# Altium schematic sheet Sheet5.SchDoc
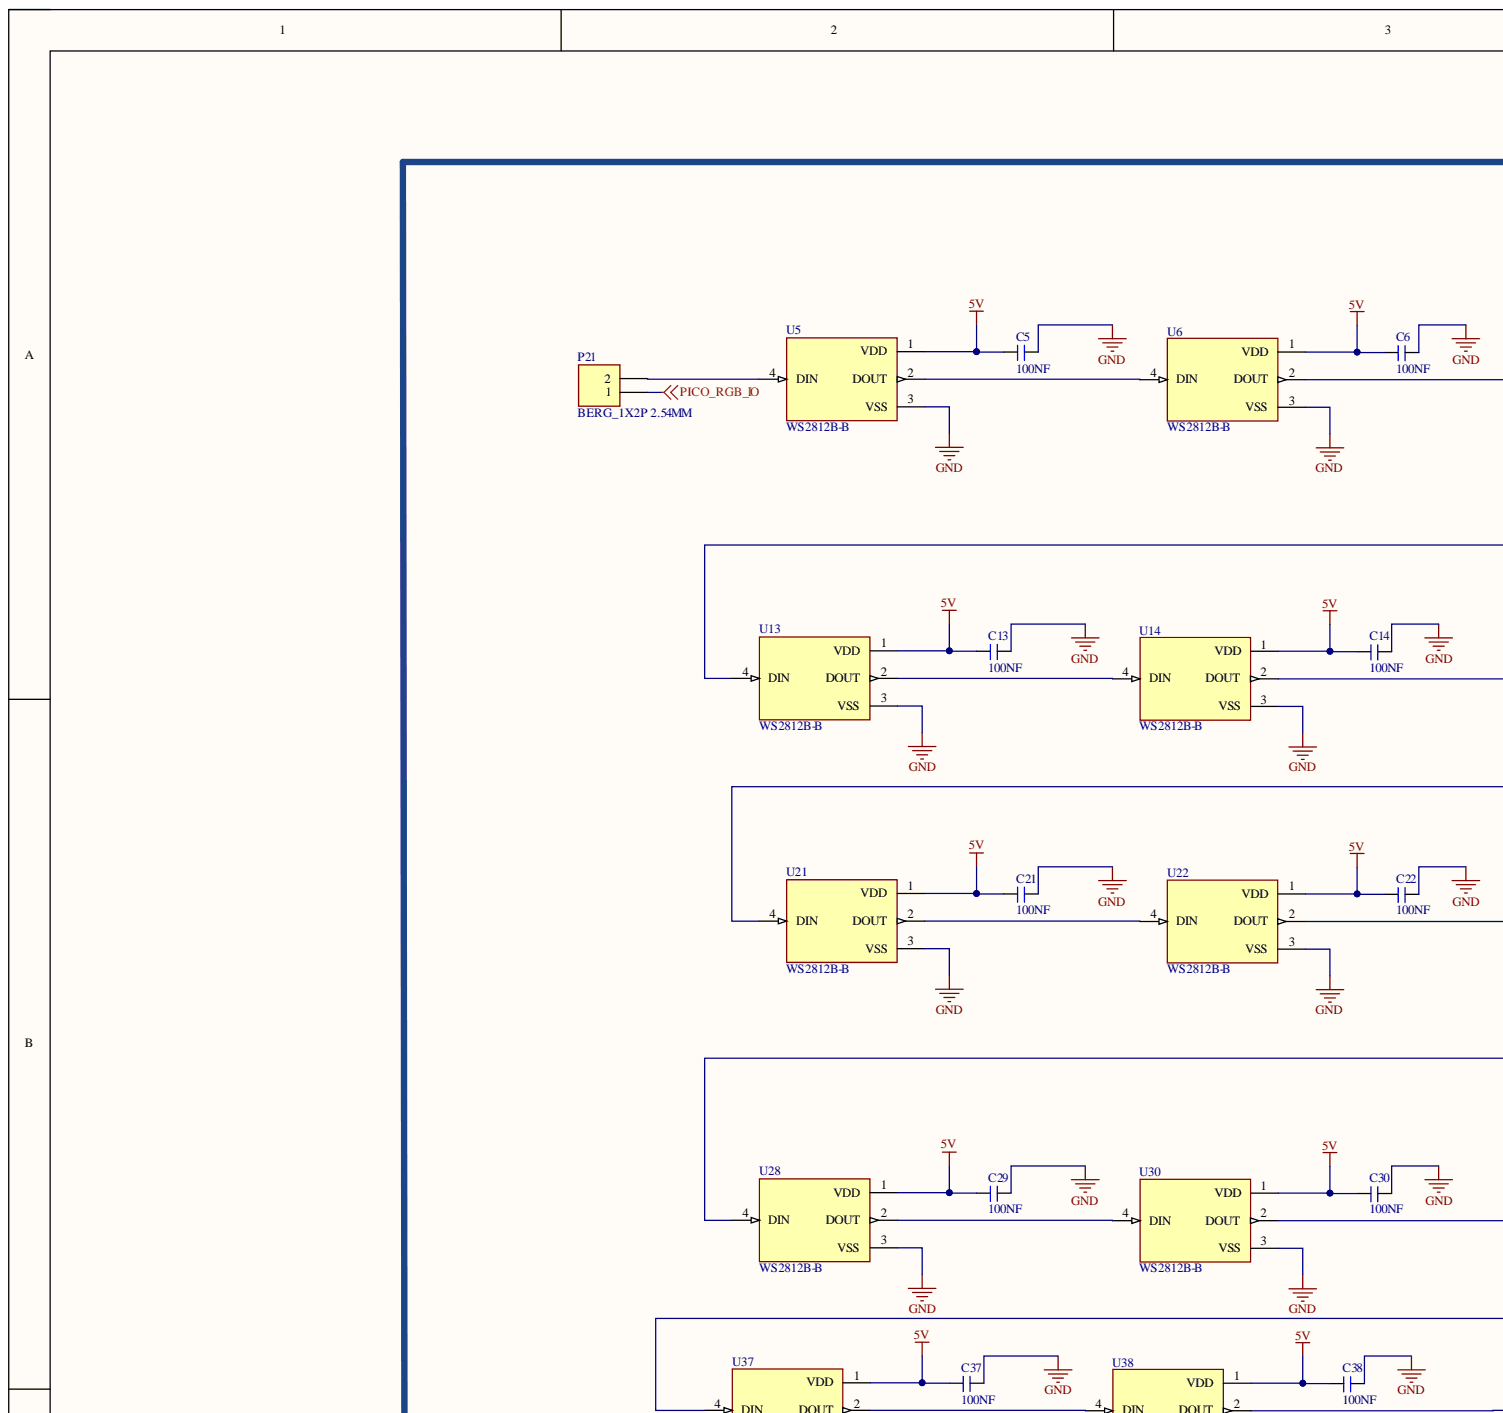
[diagram p0: Sheet5.SchDoc, top left]
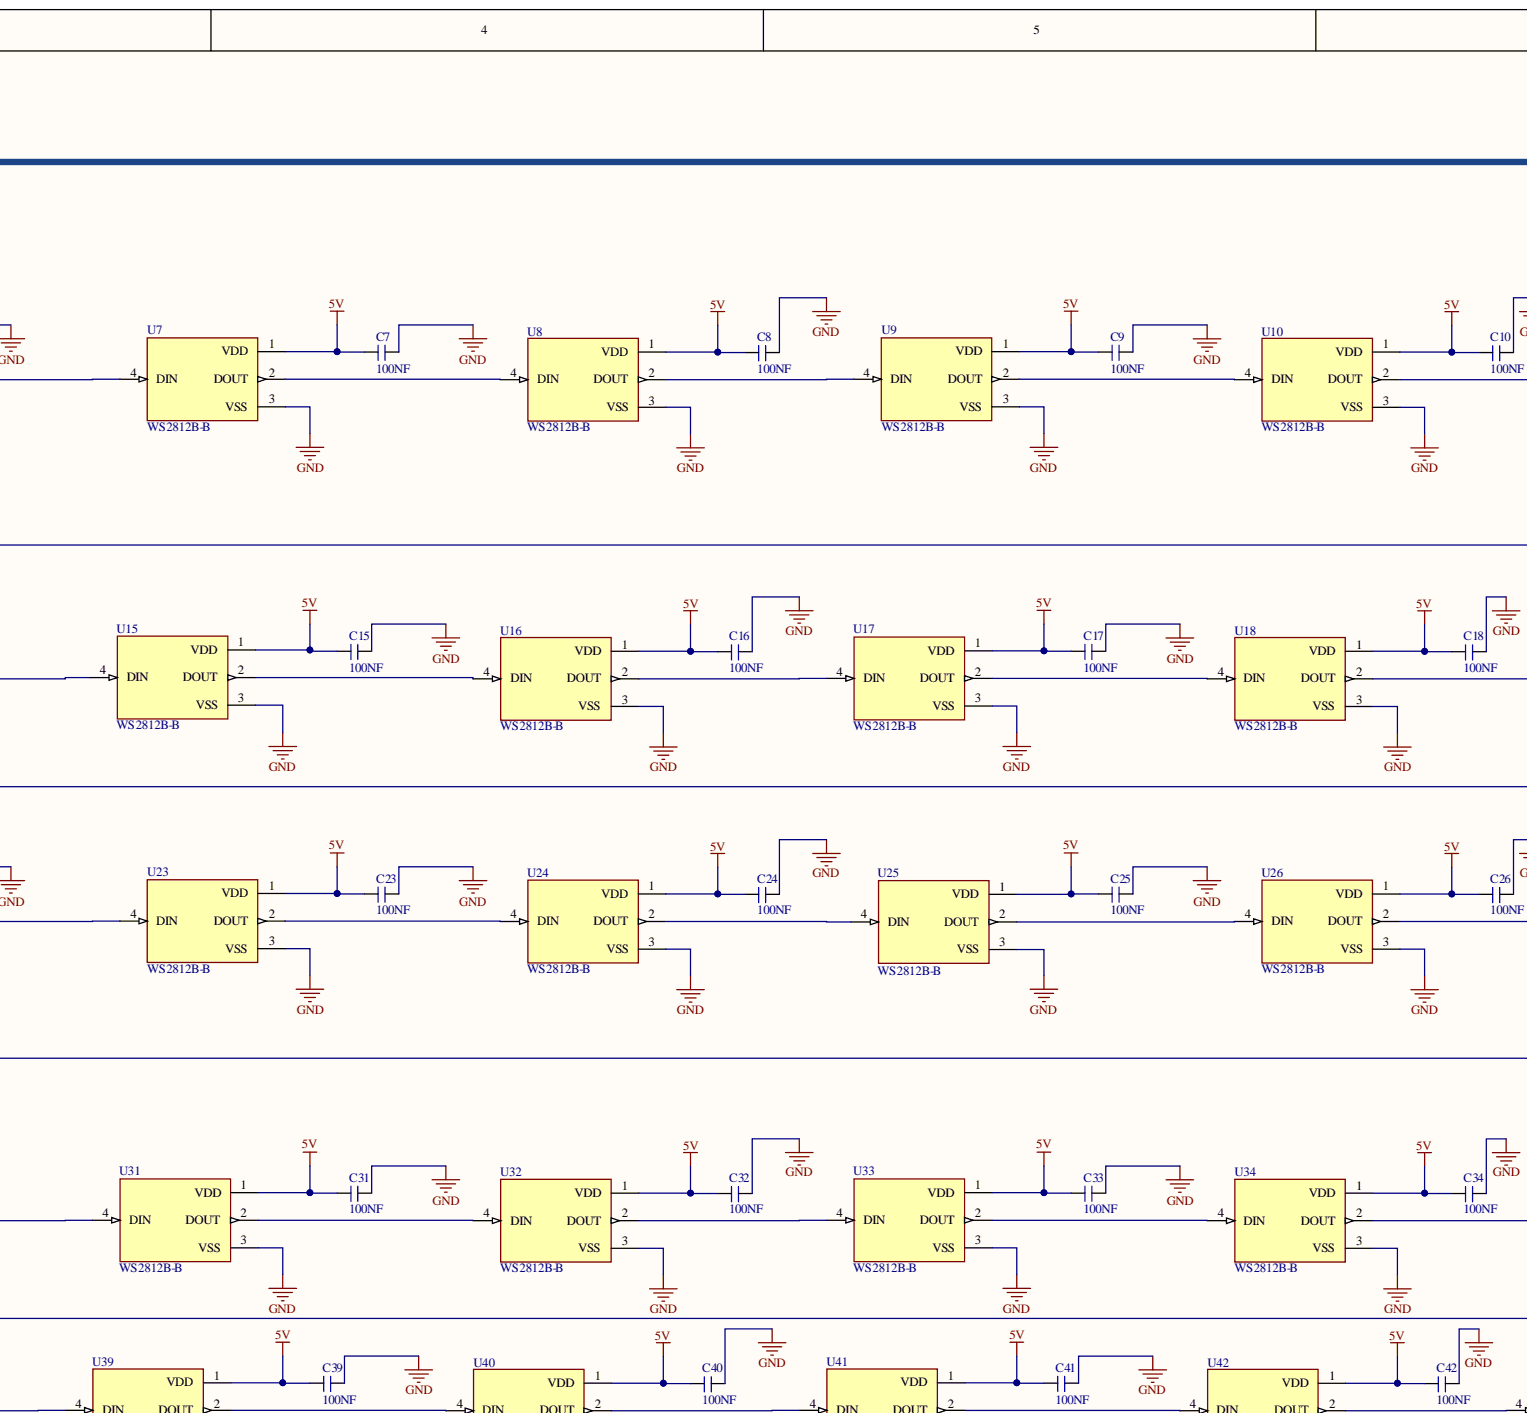
[diagram p1: Sheet5.SchDoc, top centre]
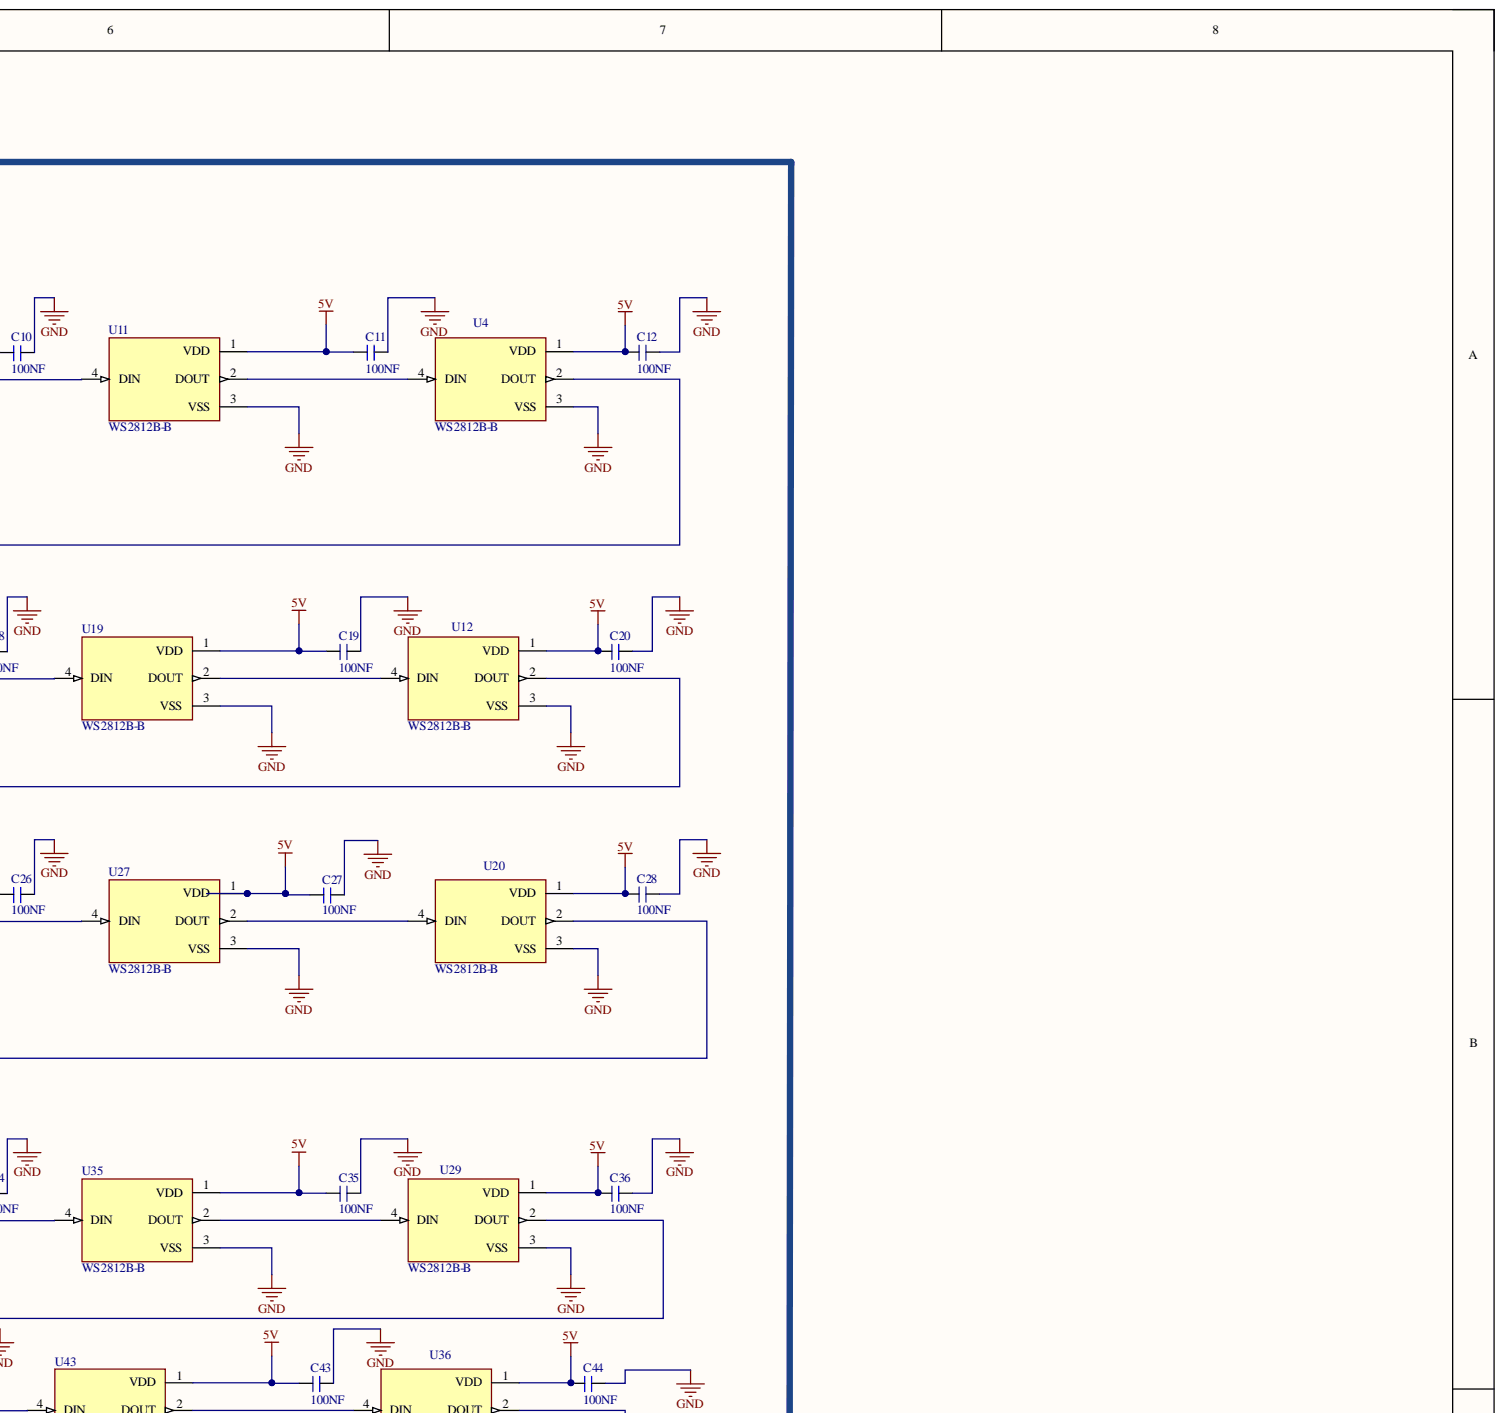
[diagram p2: Sheet5.SchDoc, top right]
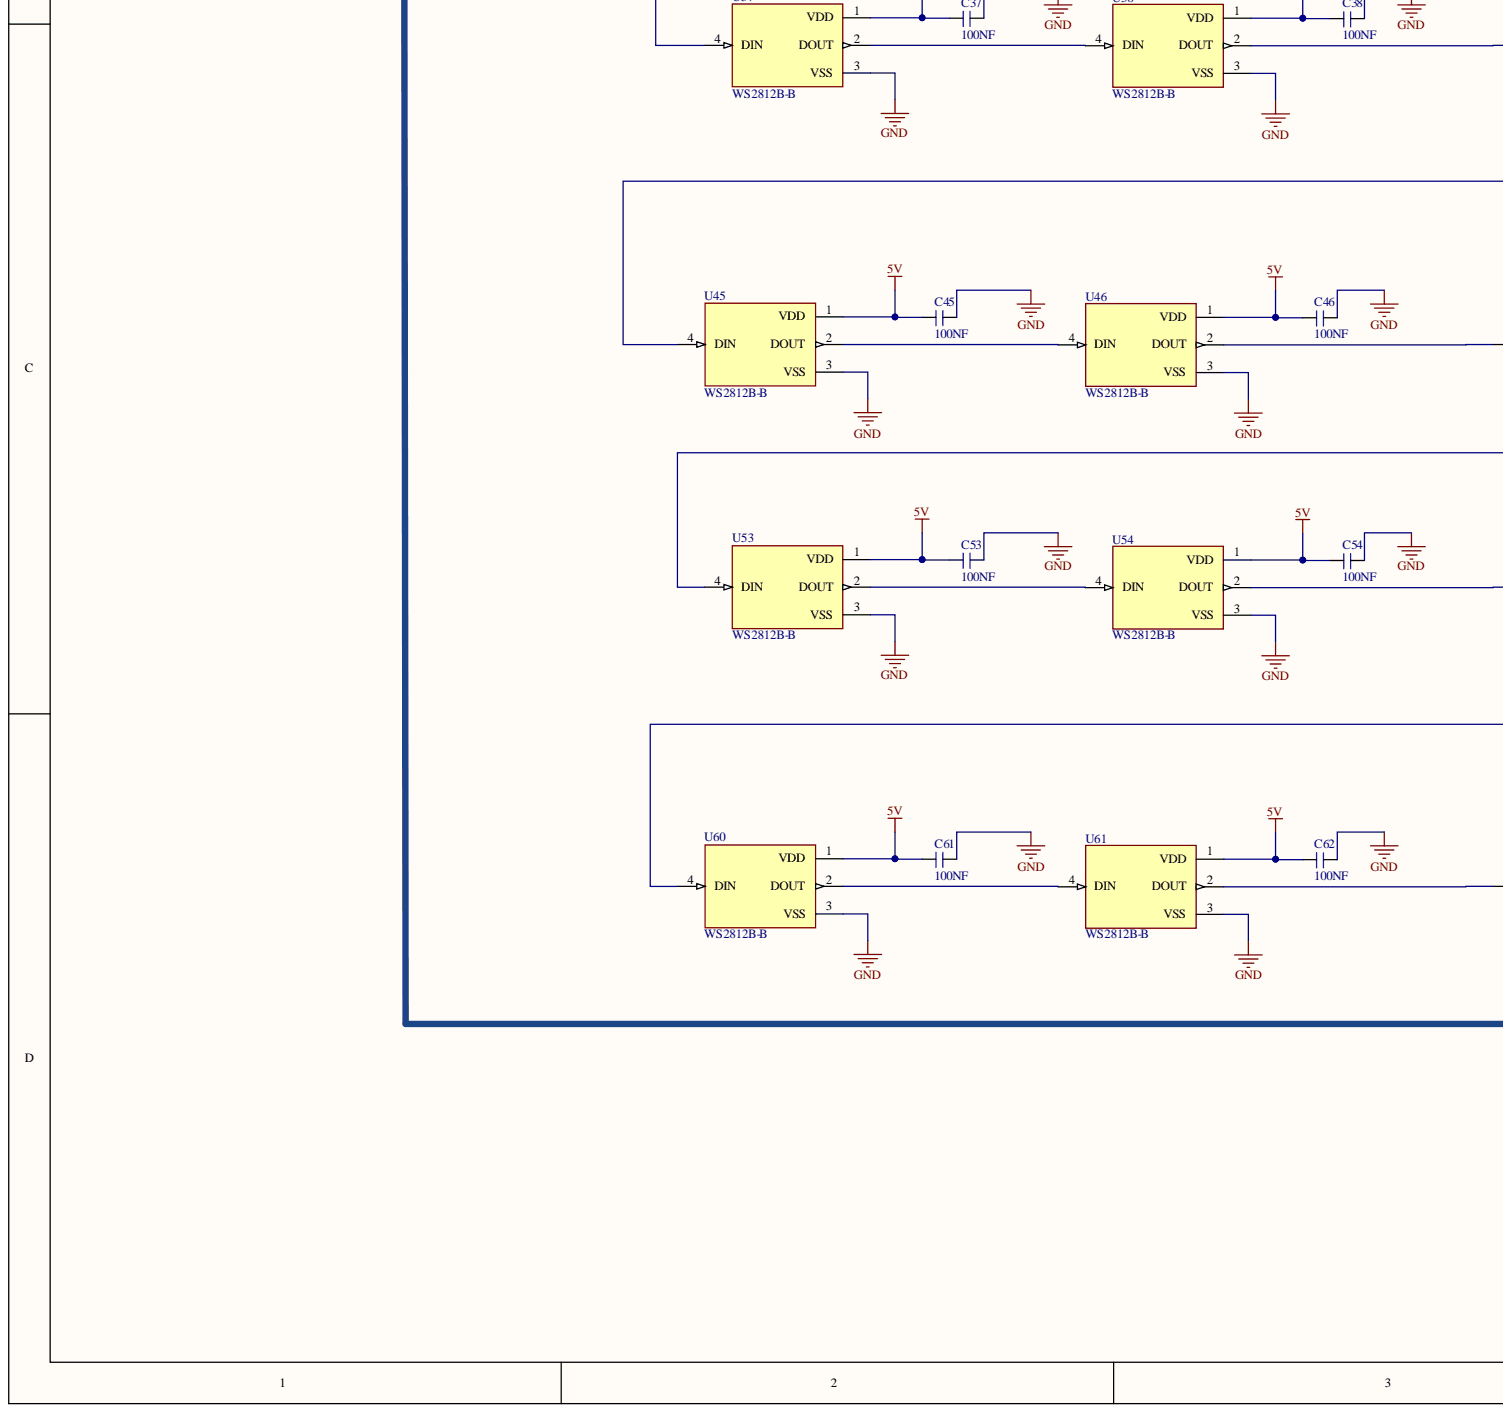
[diagram p3: Sheet5.SchDoc, bottom left]
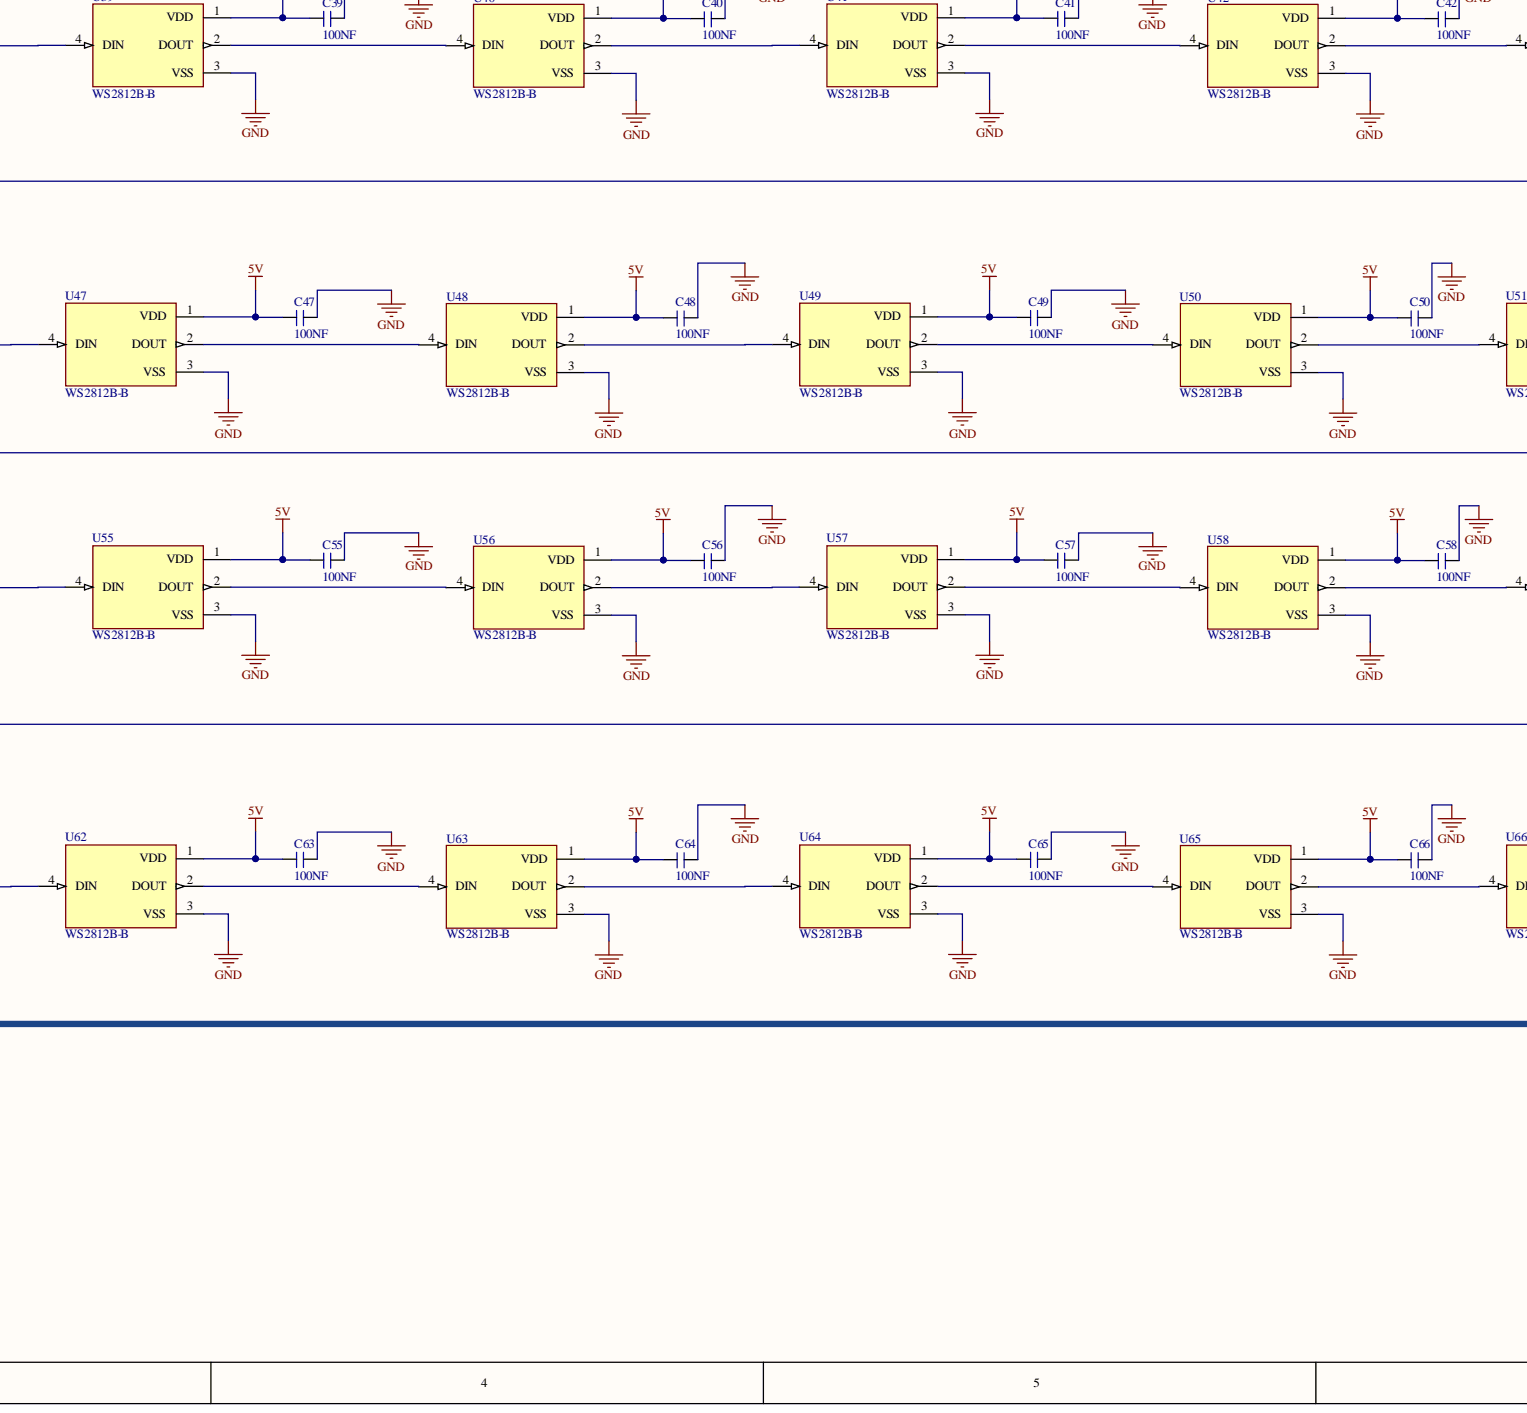
[diagram p4: Sheet5.SchDoc, bottom centre]
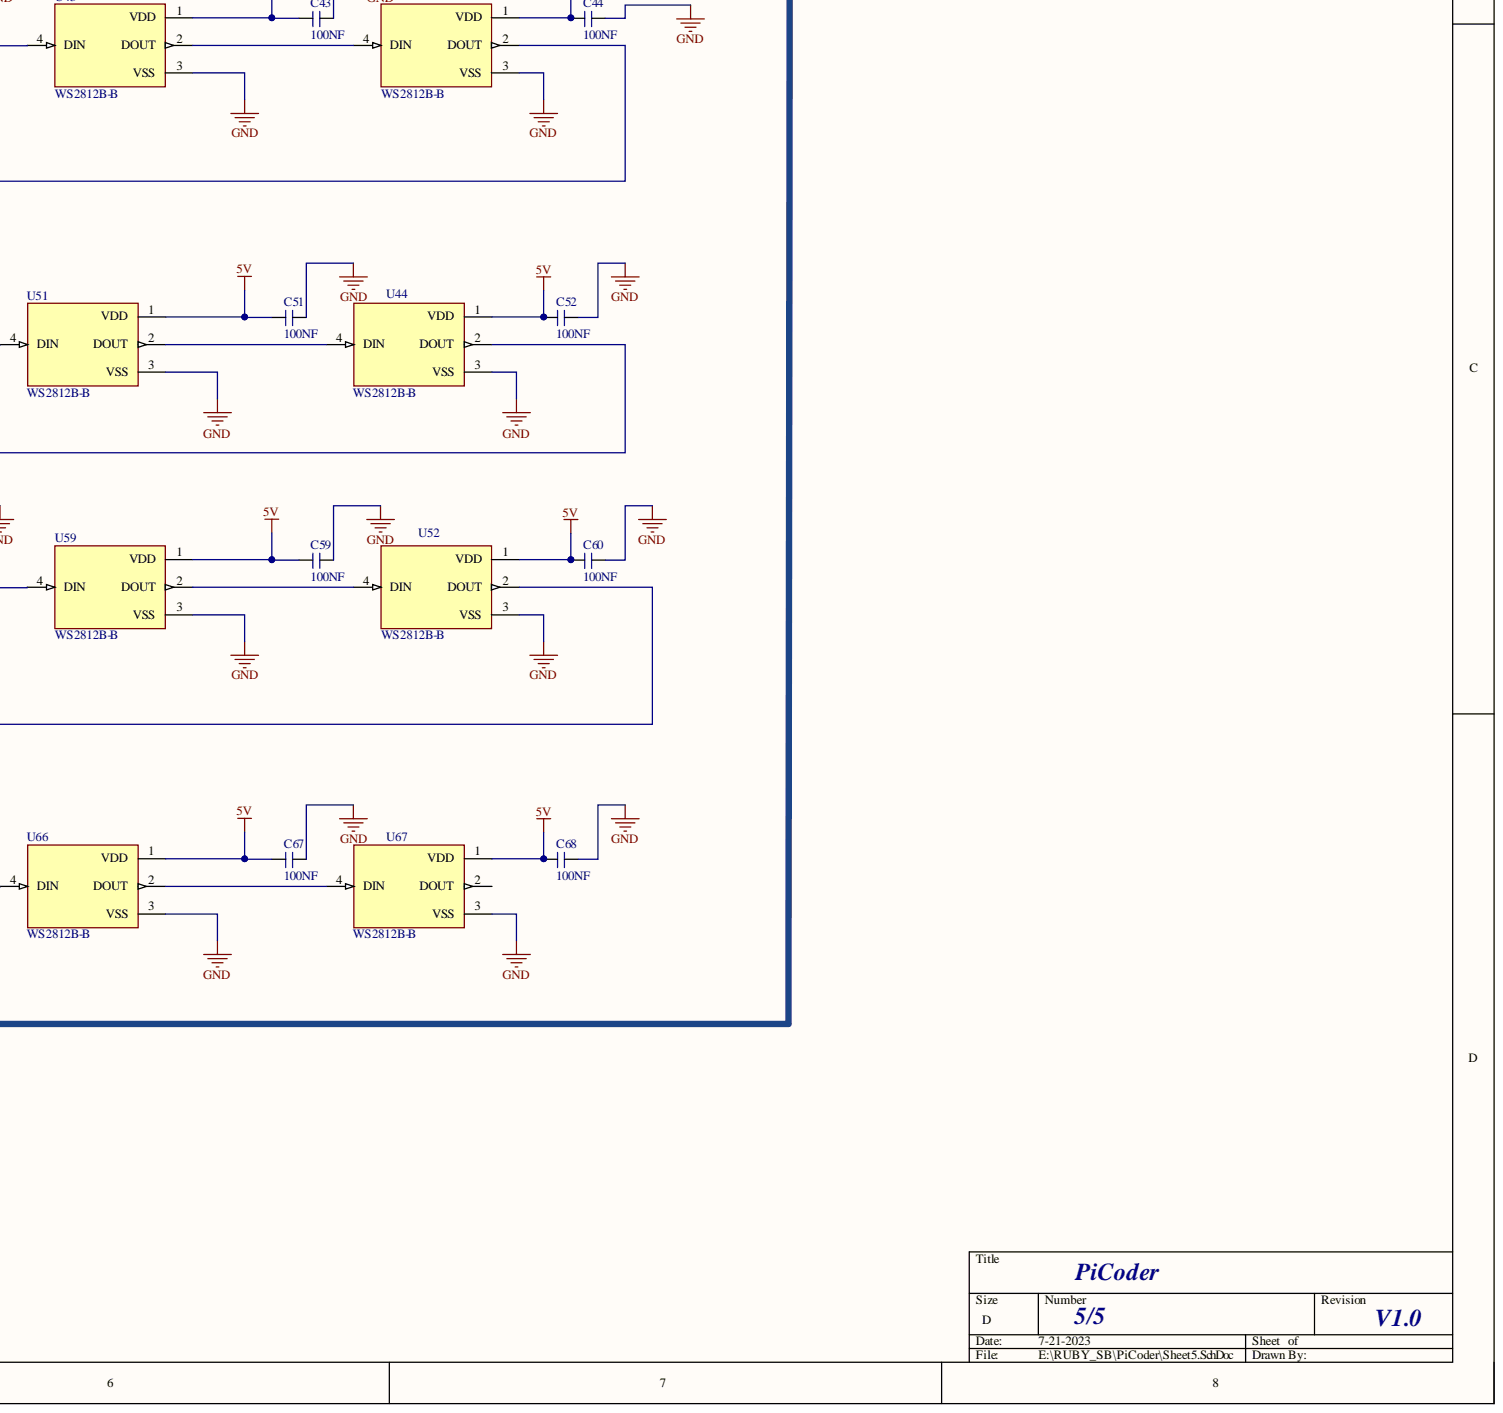
[diagram p5: Sheet5.SchDoc, bottom right]

## Parts
C5  100NF  [C_0603]
C6  100NF  [C_0603]
C7  100NF  [C_0603]
C8  100NF  [C_0603]
C9  100NF  [C_0603]
C10  100NF  [C_0603]
C11  100NF  [C_0603]
C12  100NF  [C_0603]
C13  100NF  [C_0603]
C14  100NF  [C_0603]
C15  100NF  [C_0603]
C16  100NF  [C_0603]
C17  100NF  [C_0603]
C18  100NF  [C_0603]
C19  100NF  [C_0603]
C20  100NF  [C_0603]
C21  100NF  [C_0603]
C22  100NF  [C_0603]
C23  100NF  [C_0603]
C24  100NF  [C_0603]
C25  100NF  [C_0603]
C26  100NF  [C_0603]
C27  100NF  [C_0603]
C28  100NF  [C_0603]
C29  100NF  [C_0603]
C30  100NF  [C_0603]
C31  100NF  [C_0603]
C32  100NF  [C_0603]
C33  100NF  [C_0603]
C34  100NF  [C_0603]
C35  100NF  [C_0603]
C36  100NF  [C_0603]
C37  100NF  [C_0603]
C38  100NF  [C_0603]
C39  100NF  [C_0603]
C40  100NF  [C_0603]
C41  100NF  [C_0603]
C42  100NF  [C_0603]
C43  100NF  [C_0603]
C44  100NF  [C_0603]
C45  100NF  [C_0603]
C46  100NF  [C_0603]
C47  100NF  [C_0603]
C48  100NF  [C_0603]
C49  100NF  [C_0603]
C50  100NF  [C_0603]
C51  100NF  [C_0603]
C52  100NF  [C_0603]
C53  100NF  [C_0603]
C54  100NF  [C_0603]
C55  100NF  [C_0603]
C56  100NF  [C_0603]
C57  100NF  [C_0603]
C58  100NF  [C_0603]
C59  100NF  [C_0603]
C60  100NF  [C_0603]
C61  100NF  [C_0603]
C62  100NF  [C_0603]
C63  100NF  [C_0603]
C64  100NF  [C_0603]
C65  100NF  [C_0603]
C66  100NF  [C_0603]
C67  100NF  [C_0603]
C68  100NF  [C_0603]
P21  BERG_1X2P 2.54MM  [2P_2.54MM]
U4  WS2812B-B  [WS2812B_RGB]
U5  WS2812B-B  [WS2812B_RGB]
U6  WS2812B-B  [WS2812B_RGB]
U7  WS2812B-B  [WS2812B_RGB]
U8  WS2812B-B  [WS2812B_RGB]
U9  WS2812B-B  [WS2812B_RGB]
U10  WS2812B-B  [WS2812B_RGB]
U11  WS2812B-B  [WS2812B_RGB]
U12  WS2812B-B  [WS2812B_RGB]
U13  WS2812B-B  [WS2812B_RGB]
U14  WS2812B-B  [WS2812B_RGB]
U15  WS2812B-B  [WS2812B_RGB]
U16  WS2812B-B  [WS2812B_RGB]
U17  WS2812B-B  [WS2812B_RGB]
U18  WS2812B-B  [WS2812B_RGB]
U19  WS2812B-B  [WS2812B_RGB]
U20  WS2812B-B  [WS2812B_RGB]
U21  WS2812B-B  [WS2812B_RGB]
U22  WS2812B-B  [WS2812B_RGB]
U23  WS2812B-B  [WS2812B_RGB]
U24  WS2812B-B  [WS2812B_RGB]
U25  WS2812B-B  [WS2812B_RGB]
U26  WS2812B-B  [WS2812B_RGB]
U27  WS2812B-B  [WS2812B_RGB]
U28  WS2812B-B  [WS2812B_RGB]
U29  WS2812B-B  [WS2812B_RGB]
U30  WS2812B-B  [WS2812B_RGB]
U31  WS2812B-B  [WS2812B_RGB]
U32  WS2812B-B  [WS2812B_RGB]
U33  WS2812B-B  [WS2812B_RGB]
U34  WS2812B-B  [WS2812B_RGB]
U35  WS2812B-B  [WS2812B_RGB]
U36  WS2812B-B  [WS2812B_RGB]
U37  WS2812B-B  [WS2812B_RGB]
U38  WS2812B-B  [WS2812B_RGB]
U39  WS2812B-B  [WS2812B_RGB]
U40  WS2812B-B  [WS2812B_RGB]
U41  WS2812B-B  [WS2812B_RGB]
U42  WS2812B-B  [WS2812B_RGB]
U43  WS2812B-B  [WS2812B_RGB]
U44  WS2812B-B  [WS2812B_RGB]
U45  WS2812B-B  [WS2812B_RGB]
U46  WS2812B-B  [WS2812B_RGB]
U47  WS2812B-B  [WS2812B_RGB]
U48  WS2812B-B  [WS2812B_RGB]
U49  WS2812B-B  [WS2812B_RGB]
U50  WS2812B-B  [WS2812B_RGB]
U51  WS2812B-B  [WS2812B_RGB]
U52  WS2812B-B  [WS2812B_RGB]
U53  WS2812B-B  [WS2812B_RGB]
U54  WS2812B-B  [WS2812B_RGB]
U55  WS2812B-B  [WS2812B_RGB]
U56  WS2812B-B  [WS2812B_RGB]
U57  WS2812B-B  [WS2812B_RGB]
U58  WS2812B-B  [WS2812B_RGB]
U59  WS2812B-B  [WS2812B_RGB]
U60  WS2812B-B  [WS2812B_RGB]
U61  WS2812B-B  [WS2812B_RGB]
U62  WS2812B-B  [WS2812B_RGB]
U63  WS2812B-B  [WS2812B_RGB]
U64  WS2812B-B  [WS2812B_RGB]
U65  WS2812B-B  [WS2812B_RGB]
U66  WS2812B-B  [WS2812B_RGB]
U67  WS2812B-B  [WS2812B_RGB]

## Nets
5V: C5.1 C6.1 C7.1 C8.1 C9.1 C10.1 C11.1 C12.1 C13.1 C14.1 C15.1 C16.1 C17.1 C18.1 C19.1 C20.1 C21.1 C22.1 C23.1 C24.1 C25.1 C26.1 C27.1 C28.1 C29.1 C30.1 C31.1 C32.1 C33.1 C34.1 C35.1 C36.1 C37.1 C38.1 C39.1 C40.1 C41.1 C42.1 C43.1 C44.1 C45.1 C46.1 C47.1 C48.1 C49.1 C50.1 C51.1 C52.1 C53.1 C54.1 C55.1 C56.1 C57.1 C58.1 C59.1 C60.1 C61.1 C62.1 C63.1 C64.1 C65.1 C66.1 C67.1 C68.1 U4.1(VDD) U5.1(VDD) U6.1(VDD) U7.1(VDD) U8.1(VDD) U9.1(VDD) U10.1(VDD) U11.1(VDD) U12.1(VDD) U13.1(VDD) U14.1(VDD) U15.1(VDD) U16.1(VDD) U17.1(VDD) U18.1(VDD) U19.1(VDD) U20.1(VDD) U21.1(VDD) U22.1(VDD) U23.1(VDD) U24.1(VDD) U25.1(VDD) U26.1(VDD) U27.1(VDD) U28.1(VDD) U29.1(VDD) U30.1(VDD) U31.1(VDD) U32.1(VDD) U33.1(VDD) U34.1(VDD) U35.1(VDD) U36.1(VDD) U37.1(VDD) U38.1(VDD) U39.1(VDD) U40.1(VDD) U41.1(VDD) U42.1(VDD) U43.1(VDD) U44.1(VDD) U45.1(VDD) U46.1(VDD) U47.1(VDD) U48.1(VDD) U49.1(VDD) U50.1(VDD) U51.1(VDD) U52.1(VDD) U53.1(VDD) U54.1(VDD) U55.1(VDD) U56.1(VDD) U57.1(VDD) U58.1(VDD) U59.1(VDD) U60.1(VDD) U61.1(VDD) U62.1(VDD) U63.1(VDD) U64.1(VDD) U65.1(VDD) U66.1(VDD) U67.1(VDD)
GND: C5.2 C6.2 C7.2 C8.2 C9.2 C10.2 C11.2 C12.2 C13.2 C14.2 C15.2 C16.2 C17.2 C18.2 C19.2 C20.2 C21.2 C22.2 C23.2 C24.2 C25.2 C26.2 C27.2 C28.2 C29.2 C30.2 C31.2 C32.2 C33.2 C34.2 C35.2 C36.2 C37.2 C38.2 C39.2 C40.2 C41.2 C42.2 C43.2 C44.2 C45.2 C46.2 C47.2 C48.2 C49.2 C50.2 C51.2 C52.2 C53.2 C54.2 C55.2 C56.2 C57.2 C58.2 C59.2 C60.2 C61.2 C62.2 C63.2 C64.2 C65.2 C66.2 C67.2 C68.2 U4.3(VSS) U5.3(VSS) U6.3(VSS) U7.3(VSS) U8.3(VSS) U9.3(VSS) U10.3(VSS) U11.3(VSS) U12.3(VSS) U13.3(VSS) U14.3(VSS) U15.3(VSS) U16.3(VSS) U17.3(VSS) U18.3(VSS) U19.3(VSS) U20.3(VSS) U21.3(VSS) U22.3(VSS) U23.3(VSS) U24.3(VSS) U25.3(VSS) U26.3(VSS) U27.3(VSS) U28.3(VSS) U29.3(VSS) U30.3(VSS) U31.3(VSS) U32.3(VSS) U33.3(VSS) U34.3(VSS) U35.3(VSS) U36.3(VSS) U37.3(VSS) U38.3(VSS) U39.3(VSS) U40.3(VSS) U41.3(VSS) U42.3(VSS) U43.3(VSS) U44.3(VSS) U45.3(VSS) U46.3(VSS) U47.3(VSS) U48.3(VSS) U49.3(VSS) U50.3(VSS) U51.3(VSS) U52.3(VSS) U53.3(VSS) U54.3(VSS) U55.3(VSS) U56.3(VSS) U57.3(VSS) U58.3(VSS) U59.3(VSS) U60.3(VSS) U61.3(VSS) U62.3(VSS) U63.3(VSS) U64.3(VSS) U65.3(VSS) U66.3(VSS) U67.3(VSS)
PICO_RGB_IO: P21.1
NetP21_2: P21.2 U5.4(DIN)
NetU4_2: U4.2(DOUT) U13.4(DIN)
NetU4_4: U4.4(DIN) U11.2(DOUT)
NetU5_2: U5.2(DOUT) U6.4(DIN)
NetU6_2: U6.2(DOUT) U7.4(DIN)
NetU7_2: U7.2(DOUT) U8.4(DIN)
NetU8_2: U8.2(DOUT) U9.4(DIN)
NetU9_2: U9.2(DOUT) U10.4(DIN)
NetU10_2: U10.2(DOUT) U11.4(DIN)
NetU12_2: U12.2(DOUT) U21.4(DIN)
NetU12_4: U12.4(DIN) U19.2(DOUT)
NetU13_2: U13.2(DOUT) U14.4(DIN)
NetU14_2: U14.2(DOUT) U15.4(DIN)
NetU15_2: U15.2(DOUT) U16.4(DIN)
NetU16_2: U16.2(DOUT) U17.4(DIN)
NetU17_2: U17.2(DOUT) U18.4(DIN)
NetU18_2: U18.2(DOUT) U19.4(DIN)
NetU20_2: U20.2(DOUT) U28.4(DIN)
NetU20_4: U20.4(DIN) U27.2(DOUT)
NetU21_2: U21.2(DOUT) U22.4(DIN)
NetU22_2: U22.2(DOUT) U23.4(DIN)
NetU23_2: U23.2(DOUT) U24.4(DIN)
NetU24_2: U24.2(DOUT) U25.4(DIN)
NetU25_2: U25.2(DOUT) U26.4(DIN)
NetU26_2: U26.2(DOUT) U27.4(DIN)
NetU28_2: U28.2(DOUT) U30.4(DIN)
NetU29_2: U29.2(DOUT) U37.4(DIN)
NetU29_4: U29.4(DIN) U35.2(DOUT)
NetU30_2: U30.2(DOUT) U31.4(DIN)
NetU31_2: U31.2(DOUT) U32.4(DIN)
NetU32_2: U32.2(DOUT) U33.4(DIN)
NetU33_2: U33.2(DOUT) U34.4(DIN)
NetU34_2: U34.2(DOUT) U35.4(DIN)
NetU36_2: U36.2(DOUT) U45.4(DIN)
NetU36_4: U36.4(DIN) U43.2(DOUT)
NetU37_2: U37.2(DOUT) U38.4(DIN)
NetU38_2: U38.2(DOUT) U39.4(DIN)
NetU39_2: U39.2(DOUT) U40.4(DIN)
NetU40_2: U40.2(DOUT) U41.4(DIN)
NetU41_2: U41.2(DOUT) U42.4(DIN)
NetU42_2: U42.2(DOUT) U43.4(DIN)
NetU44_2: U44.2(DOUT) U53.4(DIN)
NetU44_4: U44.4(DIN) U51.2(DOUT)
NetU45_2: U45.2(DOUT) U46.4(DIN)
NetU46_2: U46.2(DOUT) U47.4(DIN)
NetU47_2: U47.2(DOUT) U48.4(DIN)
NetU48_2: U48.2(DOUT) U49.4(DIN)
NetU49_2: U49.2(DOUT) U50.4(DIN)
NetU50_2: U50.2(DOUT) U51.4(DIN)
NetU52_2: U52.2(DOUT) U60.4(DIN)
NetU52_4: U52.4(DIN) U59.2(DOUT)
NetU53_2: U53.2(DOUT) U54.4(DIN)
NetU54_2: U54.2(DOUT) U55.4(DIN)
NetU55_2: U55.2(DOUT) U56.4(DIN)
NetU56_2: U56.2(DOUT) U57.4(DIN)
NetU57_2: U57.2(DOUT) U58.4(DIN)
NetU58_2: U58.2(DOUT) U59.4(DIN)
NetU60_2: U60.2(DOUT) U61.4(DIN)
NetU61_2: U61.2(DOUT) U62.4(DIN)
NetU62_2: U62.2(DOUT) U63.4(DIN)
NetU63_2: U63.2(DOUT) U64.4(DIN)
NetU64_2: U64.2(DOUT) U65.4(DIN)
NetU65_2: U65.2(DOUT) U66.4(DIN)
NetU66_2: U66.2(DOUT) U67.4(DIN)

Not connected: U67 2(DOUT)

## Library data of the parts
C5-C68 = CAP_NONPOLAR: Component Kind: Standard; Library Name: dxp_pasl_lib.IntLib
P21 = Header 2: Header, 2-Pin; Component Kind: Standard; LatestRevisionDate: 17-Jul-2002; LatestRevisionNote: Re-released for DXP Platform.; Library Name: Miscellaneous Connectors.IntLib; Signal Integrity: Connector; Publisher: Altium Limited
U4-U67 = WS2812B-B: Intelligent control LED integrated light source; Availability: Unavailable; Component Kind: Standard; Library Name: WS2812B-B.SchLib; MP: WS2812B-B; Package: None; Price: None
